# Altium schematic sheet [03] - Inter-connects.SchDoc("[03] - Inter-connects")
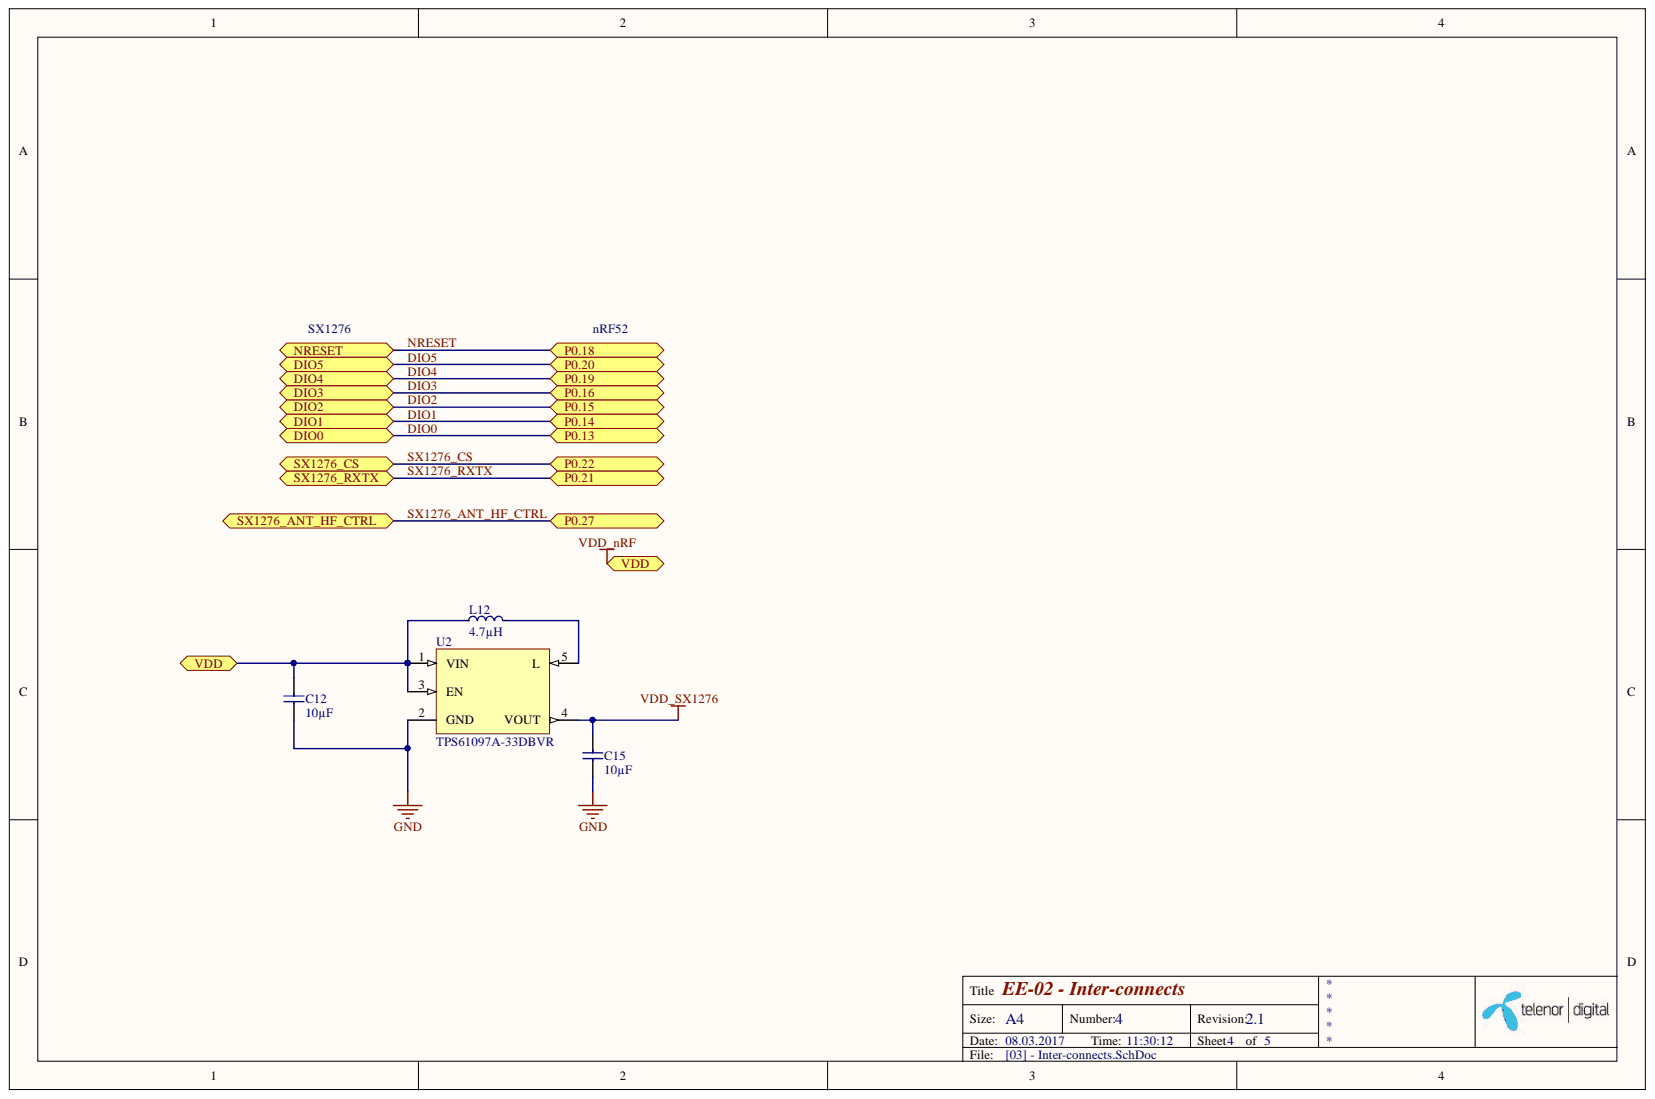
[diagram p0: [03] - Inter-connects.SchDoc]

## Parts
C12  10µF  [CAPC1005X04L]
C15  10µF  [CAPC1005X04L]
L12  4.7µH  [INDC1608X06L]
U2  TPS61097A-33DBVR  [SOT95P280X145-5L]

## Ports
DIO0, DIO1, DIO2, DIO3, DIO4, DIO5, NRESET, P0.13, P0.14, P0.15, P0.16, P0.18, P0.19, P0.20, P0.21, P0.22, P0.27, SX1276_ANT_HF_CTRL, SX1276_CS, SX1276_RXTX, VDD

## Nets
GND: C12.1 C15.1 U2.2(GND)
VDD_SX1276: C15.2 U2.4(VOUT)
VDD_nRF: C12.2 L12.1 U2.1(VIN) U2.3(EN) ; port VDD
NetL12_2: L12.2 U2.5(L)
DIO0: ; port DIO0, port P0.13
DIO1: ; port DIO1, port P0.14
DIO2: ; port DIO2, port P0.15
DIO3: ; port DIO3, port P0.16
DIO4: ; port DIO4, port P0.19
DIO5: ; port DIO5, port P0.20
NRESET: ; port NRESET, port P0.18
SX1276_ANT_HF_CTRL: ; port P0.27, port SX1276_ANT_HF_CTRL
SX1276_CS: ; port P0.22, port SX1276_CS
SX1276_RXTX: ; port P0.21, port SX1276_RXTX

## Library data of the parts
C12 C15 = GRM155R60J106ME15D: CAP CER 10UF 6.3V X5R 0402; Manufacturer: Murata Electronics North America; Tolerance: ±20%; Voltage - Rated: 6.3V; Value: 10µF; Thickness (Max): 0.026" (0.65mm); Temperature Coefficient: X5R; Supplier Part Number 1: 490-13238-6-ND; Supplier 1: Digi-Key; Stock 1: 46293; Size / Dimension: 0.039" L x 0.020" W (1.00mm x 0.50mm); RoHS: RoHS Compliant; Ratings: -; Pricing 1: 1=0.23, 10=0.159, 50=0.0966, 100=0.0811, 250=0.06564, 500=0.0579, 1000=0.04922, 5000=0.0415 (USD); Part Status: Active; Packaging: Digi-Reel®; Package / Case: 0402 (1005 Metric); Operating Temperature: -55°C ~ 85°C; Mounting Type: Surface Mount, MLCC; Manufacturer Part Number: GRM155R60J106ME15D; Lead Style: -; Lead Spacing: -; Height - Seated (Max): -; Features: Derating Recommended; ComponentLink1URL: http://psearch.en.murata.com/capacitor/product/GRM155R60J106ME15#.pdf; ComponentLink1Description: http://psearch.en.murata.com/capacitor/product/GRM155R60J106ME15#.pdf; Component Kind: Standard; Category: Capacitors; Capacitance: 10µF; Applications: General Purpose; Library Name: Skunkworks Library.IntLib
L12 = MLZ1608M4R7WT000: FIXED IND 4.7UH 350MA 500 MOHM; Manufacturer: TDK Corporation; Type: Multilayer; Tolerance: ±20%; Shielding: Shielded; Material - Core: Ferrite; Frequency - Test: 2MHz; DC Resistance (DCR): 650 mOhm Max; Current - Saturation: 120mA; Value: 4.7µH; Supplier Part Number 1: 445-6390-6-ND; Supplier 1: Digi-Key; Stock 1: 40675; Size / Dimension: 0.063" L x 0.031" W (1.60mm x 0.80mm); RoHS: RoHS Compliant; Ratings: -; Q @ Freq: -; Pricing 1: 1=0.17, 10=0.15, 50=0.117, 100=0.105, 500=0.09, 1000=0.078 (USD); Part Status: Active; Packaging: Digi-Reel®; Package / Case: 0603 (1608 Metric); Operating Temperature: -55°C ~ 125°C; Mounting Type: Surface Mount; Manufacturer Part Number: MLZ1608M4R7WT000; Inductance: 4.7µH; Height - Seated (Max): 0.037" (0.95mm); Frequency - Self Resonant: -; Current Rating: 350mA; ComponentLink1URL: https://product.tdk.com/info/en/catalog/datasheets/inductor_commercial_decoupling_mlz1608_en.pdf; ComponentLink1Description: https://product.tdk.com/info/en/catalog/datasheets/inductor_commercial_decoupling_mlz1608_en.pdf; Component Kind: Standard; Category: Inductors, Coils, Chokes; Library Name: Skunkworks Library.IntLib
U2 = TPS61097A-33DBVR: IC REG BST 3.3V 0.2A SYNC SOT23; Manufacturer: Texas Instruments; Voltage - Input (Min): 0.9V; Voltage - Input (Max): 5.5V; Topology: Boost; Synchronous Rectifier: Yes; Output Type: Fixed; Output Configuration: Positive; Number of Outputs: 1; Function: Step-Up; Current - Output: 200mA (Switch); Voltage - Output (Min/Fixed): 3.3V; Voltage - Output (Max): -; Value: TPS61097A-33DBVR; Supplier Part Number 1: 296-44398-6-ND; Supplier Device Package: SOT-23-5; Supplier 1: Digi-Key; Stock 1: 4005; RoHS: RoHS Compliant; Pricing 1: 1=1.92, 10=1.72, 100=1.3797, 500=1.134, 1000=0.7875 (USD); Part Status: Active; Packaging: Digi-Reel®; Package / Case: SC-74A, SOT-753; Operating Temperature: -40°C ~ 85°C (TA); Mounting Type: Surface Mount; Manufacturer Part Number: TPS61097A-33DBVR; Frequency - Switching: -; ComponentLink1URL: http://www.ti.com/lit/ds/symlink/tps61097a-33.pdf; ComponentLink1Description: http://www.ti.com/lit/ds/symlink/tps61097a-33.pdf; Component Kind: Standard; Category: Integrated Circuits (ICs); Library Name: Skunkworks Library.IntLib
